# Altium schematic sheet 19_SOLEDNOID.SchDoc(19_SOLEDNOID)
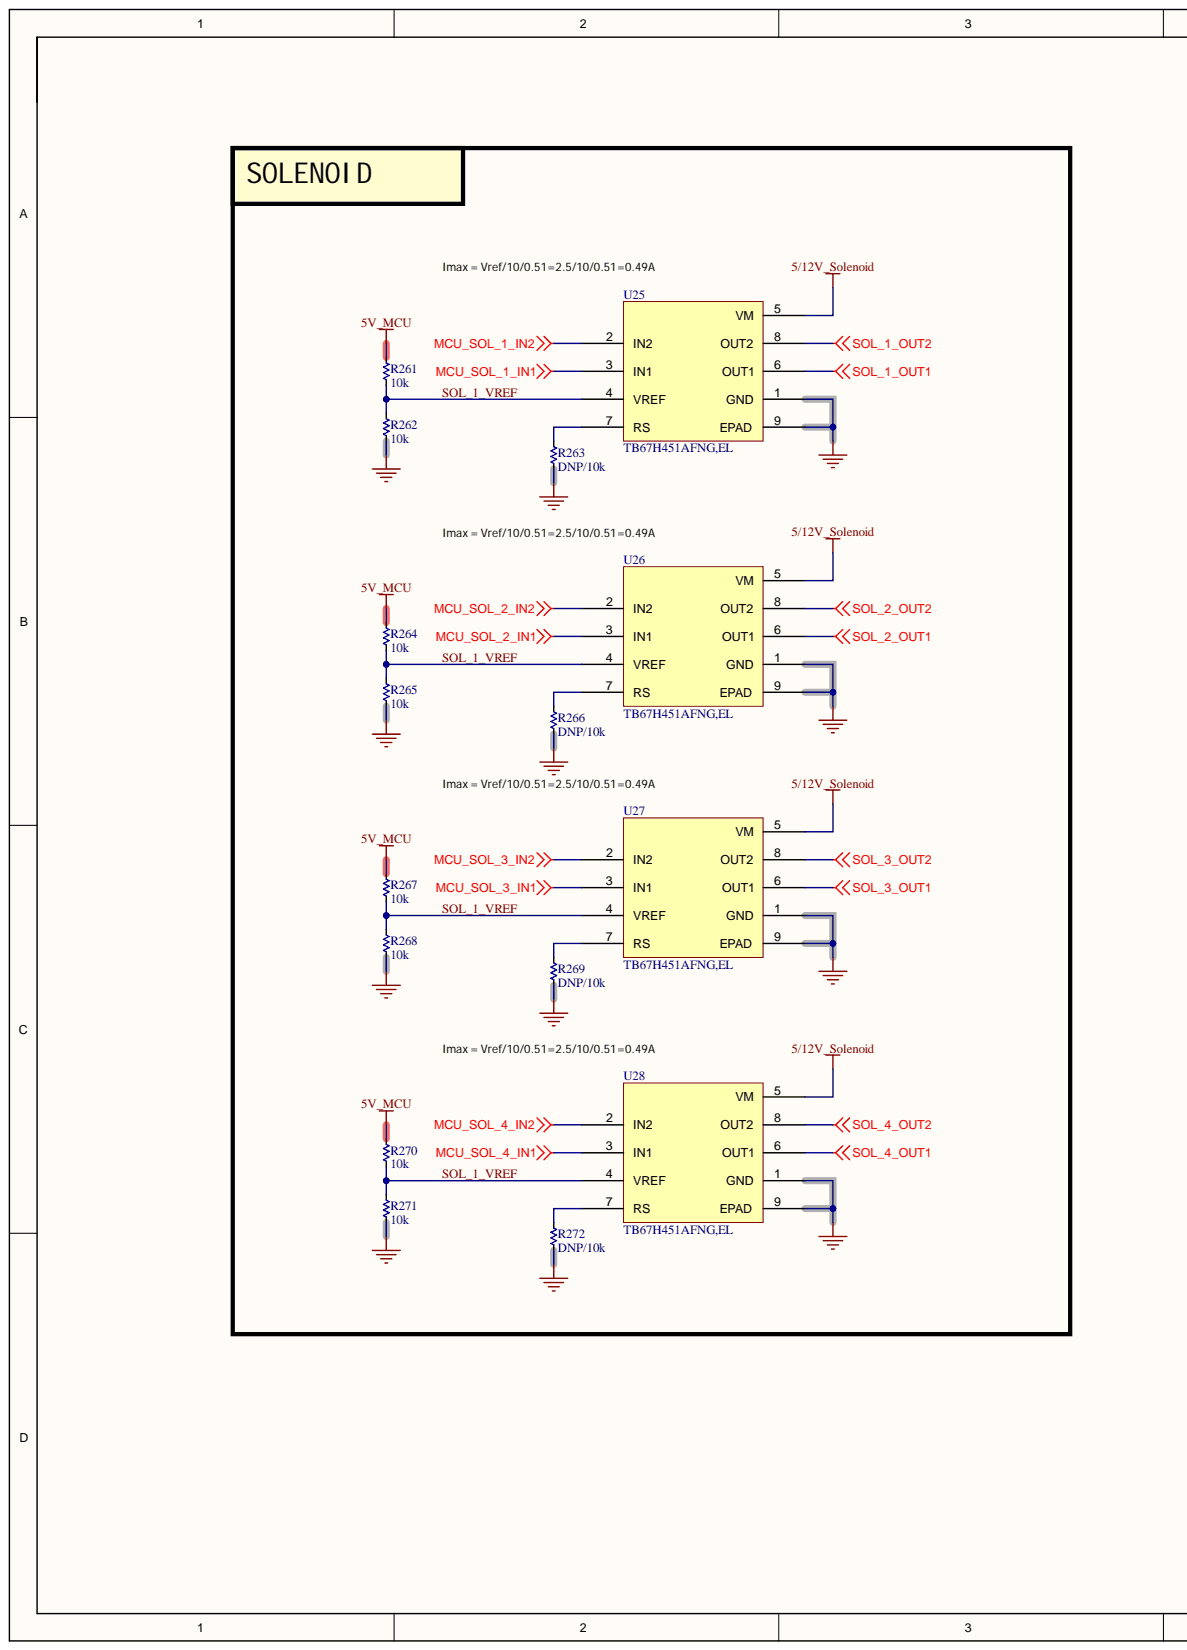
[diagram p0: 19_SOLEDNOID.SchDoc, left]
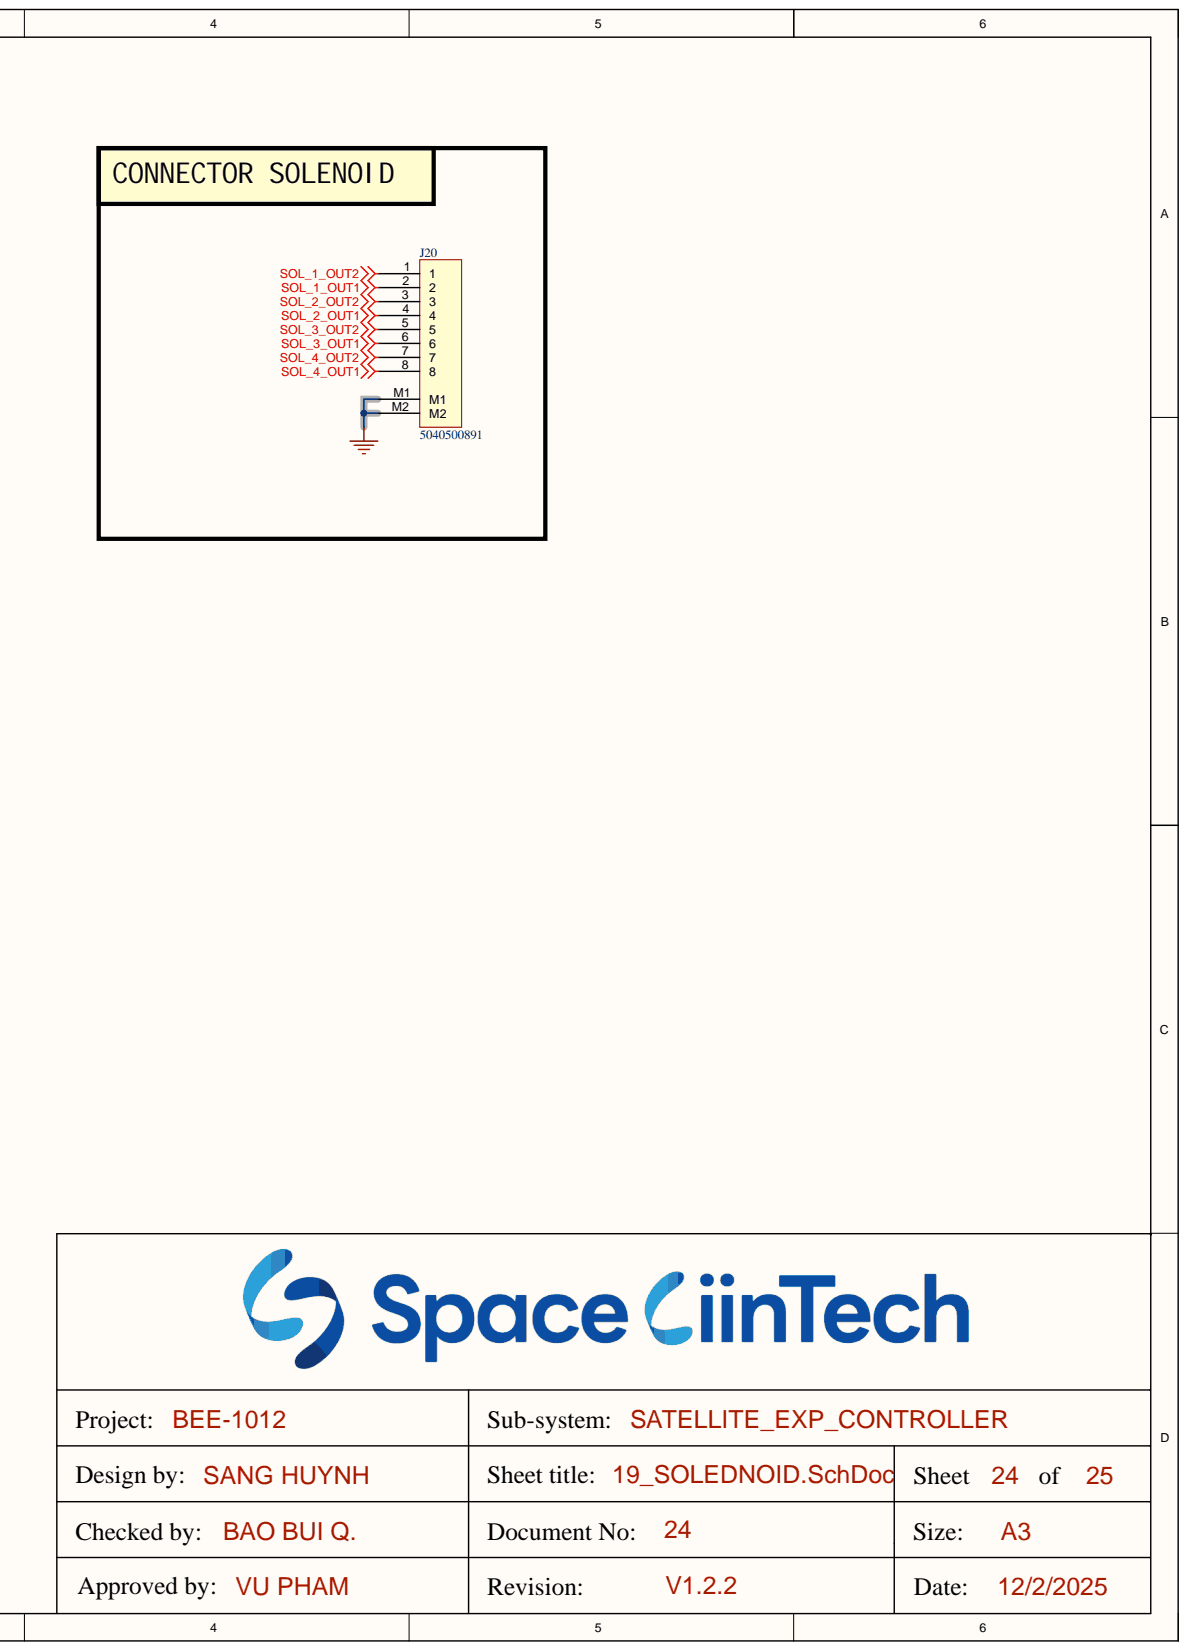
[diagram p1: 19_SOLEDNOID.SchDoc, right]

## Parts
J20  5040500891  [MOLEX_5040500891]
R261  10k  [RES_C_1005X40]
R262  10k  [RES_C_1005X40]
R263  DNP/10k  [RES_C_1005X40]
R264  10k  [RES_C_1005X40]
R265  10k  [RES_C_1005X40]
R266  DNP/10k  [RES_C_1005X40]
R267  10k  [RES_C_1005X40]
R268  10k  [RES_C_1005X40]
R269  DNP/10k  [RES_C_1005X40]
R270  10k  [RES_C_1005X40]
R271  10k  [RES_C_1005X40]
R272  DNP/10k  [RES_C_1005X40]
U25  TB67H451AFNG,EL
U26  TB67H451AFNG,EL
U27  TB67H451AFNG,EL
U28  TB67H451AFNG,EL

## Nets
5/12V_Solenoid: U25.5(VM) U26.5(VM) U27.5(VM) U28.5(VM)
5V_MCU: R261.1 R264.1 R267.1 R270.1
GND: J20.M1 J20.M2 R262.2 R263.2 R265.2 R266.2 R268.2 R269.2 R271.2 R272.2 U25.1(GND) U25.9(EPAD) U26.1(GND) U26.9(EPAD) U27.1(GND) U27.9(EPAD) U28.1(GND) U28.9(EPAD)
MCU_SOL_1_IN1: U25.3(IN1)
MCU_SOL_1_IN2: U25.2(IN2)
MCU_SOL_2_IN1: U26.3(IN1)
MCU_SOL_2_IN2: U26.2(IN2)
MCU_SOL_3_IN1: U27.3(IN1)
MCU_SOL_3_IN2: U27.2(IN2)
MCU_SOL_4_IN1: U28.3(IN1)
MCU_SOL_4_IN2: U28.2(IN2)
SOL_1_OUT1: J20.2 U25.6(OUT1)
SOL_1_OUT2: J20.1 U25.8(OUT2)
SOL_2_OUT1: J20.4 U26.6(OUT1)
SOL_2_OUT2: J20.3 U26.8(OUT2)
SOL_3_OUT1: J20.6 U27.6(OUT1)
SOL_3_OUT2: J20.5 U27.8(OUT2)
SOL_4_OUT1: J20.8 U28.6(OUT1)
SOL_4_OUT2: J20.7 U28.8(OUT2)
SOL_1_VREF: R261.2 R262.1 R264.2 R265.1 R267.2 R268.1 R270.2 R271.1 U25.4(VREF) U26.4(VREF) U27.4(VREF) U28.4(VREF)
NetR263_1: R263.1 U25.7(RS)
NetR266_1: R266.1 U26.7(RS)
NetR269_1: R269.1 U27.7(RS)
NetR272_1: R272.1 U28.7(RS)

## Library data of the parts
J20 = Header 8x1_2M: Component Kind: Standard; Library Name: LABLIB.SchLib
R261-R272 = _RES_B_2: RES; Component Kind: Standard; Library Name: LABLIB.SchLib
U25-U28 = IC_H_bridge_TB67H451AFNG,EL: BRUSHED MOTOR DRIVER IC, 50V, 3.; Component Kind: Standard; Library Name: LABLIB.SchLib
